# Altium schematic sheet MAX6456_1.SchDoc(MAX6456_1)
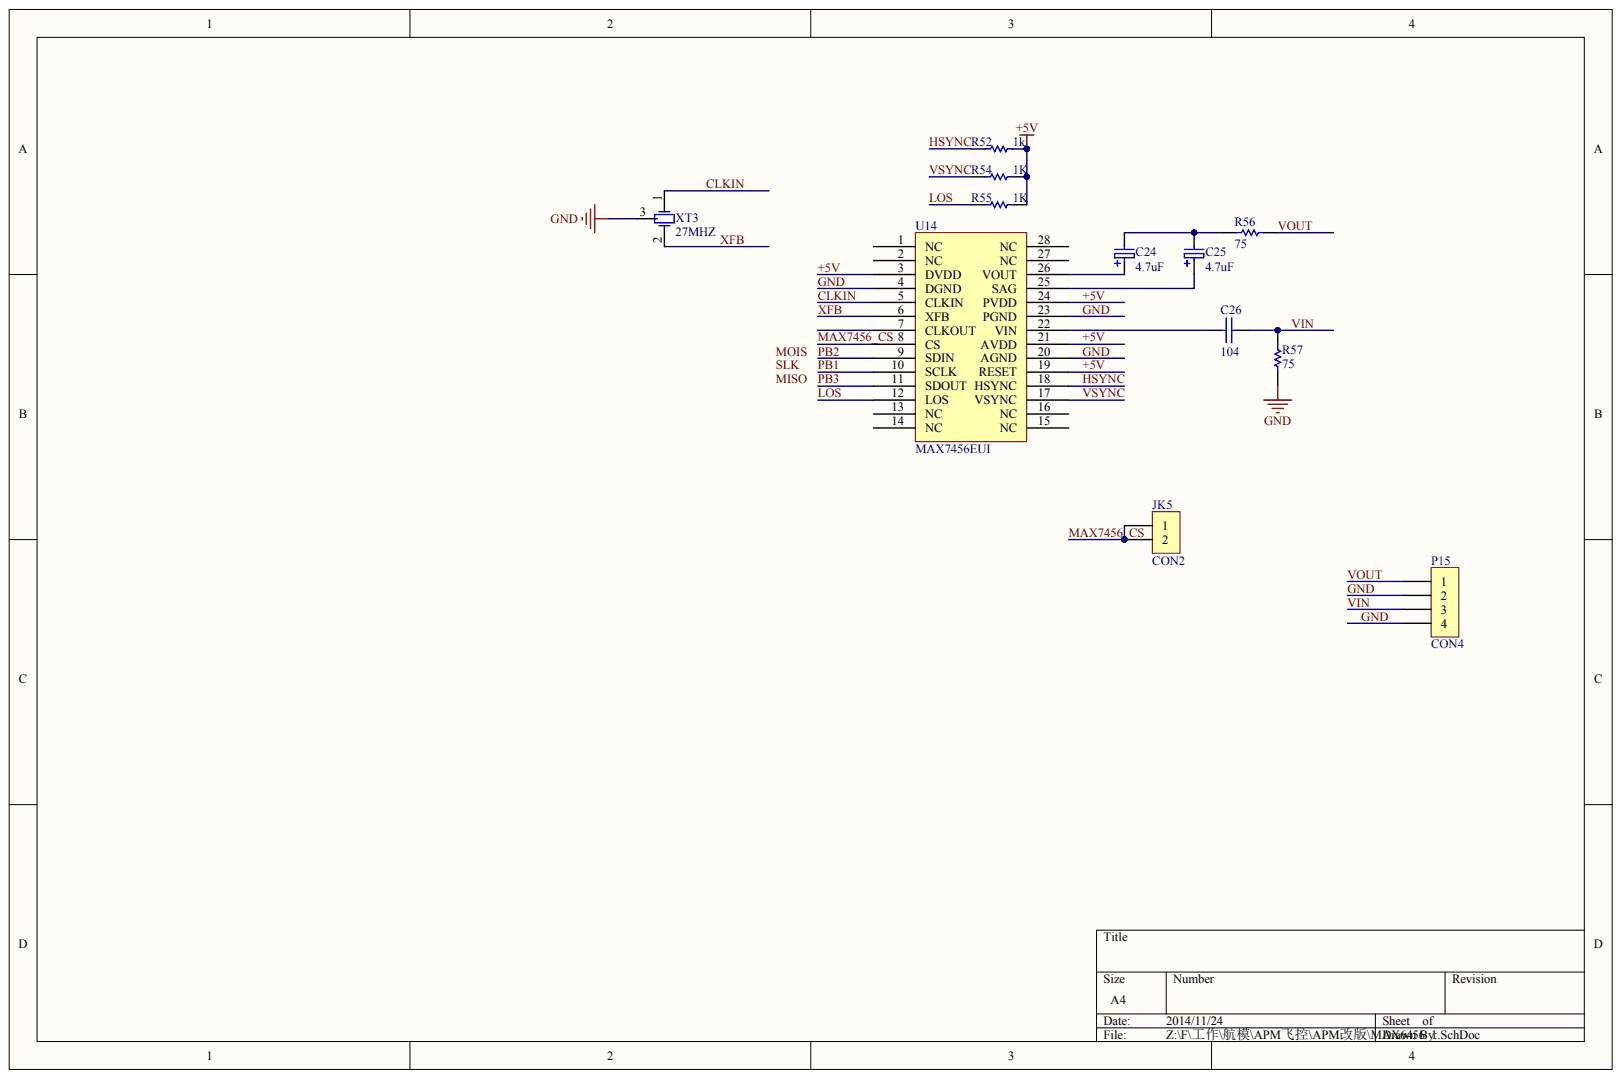
[diagram p0: MAX6456_1.SchDoc]

## Parts
C24  4.7uF
C25  4.7uF
C26  104
JK5  CON2
P15  CON4
R52  1k
R54  1K
R55  1K
R56  75
R57  75
U14  MAX7456EUI
XT3  27MHZ

## Nets
+5V: R52.1 R54.2 R55.2 U14.3(DVDD) U14.19(RESET) U14.21(AVDD) U14.24(PVDD)
CLKIN: U14.5(CLKIN) XT3.1
GND: P15.2 P15.4 R57.1 U14.4(DGND) U14.20(AGND) U14.23(PGND) XT3.3
HSYNC: R52.2 U14.18(HSYNC)
LOS: R55.1 U14.12(LOS)
MAX7456_CS: JK5.1 JK5.2 U14.8(CS)
PB1: U14.10(SCLK)
PB2: U14.9(SDIN)
PB3: U14.11(SDOUT)
VIN: C26.2 P15.3 R57.2
VOUT: P15.1 R56.2
VSYNC: R54.1 U14.17(VSYNC)
XFB: U14.6(XFB) XT3.2
NetC24_1: C24.1 U14.26(VOUT)
NetC24_2: C24.2 C25.2 R56.1
NetC25_1: C25.1 U14.25(SAG)
NetC26_1: C26.1 U14.22(VIN)
MISO:
MOIS:
SLK:

Not connected: U14 1(NC) 2(NC) 7(CLKOUT) 13(NC) 14(NC) 15(NC) 16(NC) 27(NC) 28(NC)
